# Altium schematic sheet Hardware.SchDoc(Hardware)
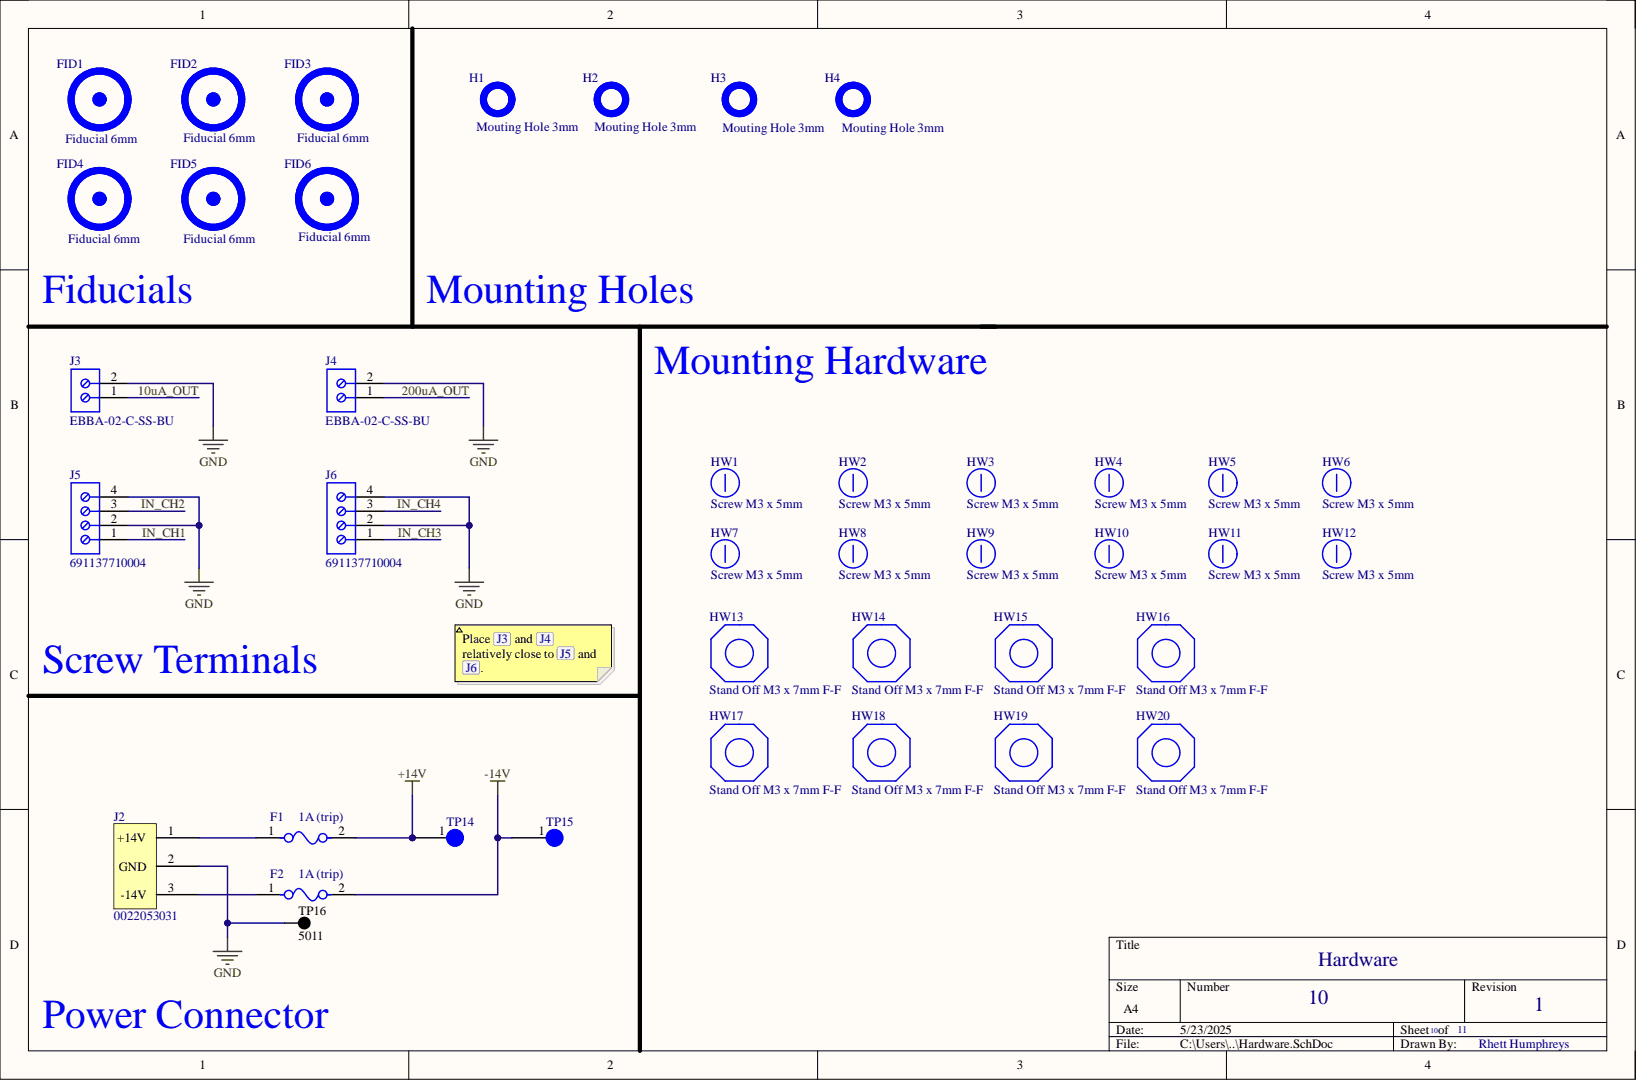
[diagram p0: Hardware.SchDoc]

## Parts
F1  1A (trip)  Fuse Current: 1A  [FP-0466-MFG]
F2  1A (trip)  Fuse Current: 1A  [FP-0466-MFG]
FID1  Fiducial 6mm  [Fiducial 6mm]
FID2  Fiducial 6mm  [Fiducial 6mm]
FID3  Fiducial 6mm  [Fiducial 6mm]
FID4  Fiducial 6mm  [Fiducial 6mm]
FID5  Fiducial 6mm  [Fiducial 6mm]
FID6  Fiducial 6mm  [Fiducial 6mm]
H1  Mouting Hole 3mm  [MH3]
H2  Mouting Hole 3mm  [MH3]
H3  Mouting Hole 3mm  [MH3]
H4  Mouting Hole 3mm  [MH3]
HW1  Screw M3 x 5mm
HW2  Screw M3 x 5mm
HW3  Screw M3 x 5mm
HW4  Screw M3 x 5mm
HW5  Screw M3 x 5mm
HW6  Screw M3 x 5mm
HW7  Screw M3 x 5mm
HW8  Screw M3 x 5mm
HW9  Screw M3 x 5mm
HW10  Screw M3 x 5mm
HW11  Screw M3 x 5mm
HW12  Screw M3 x 5mm
HW13  Stand Off M3 x 7mm F-F
HW14  Stand Off M3 x 7mm F-F
HW15  Stand Off M3 x 7mm F-F
HW16  Stand Off M3 x 7mm F-F
HW17  Stand Off M3 x 7mm F-F
HW18  Stand Off M3 x 7mm F-F
HW19  Stand Off M3 x 7mm F-F
HW20  Stand Off M3 x 7mm F-F
J2  0022053031  [0022053031]
J3  EBBA-02-C-SS-BU  [TECO-282836-2_V]
J4  EBBA-02-C-SS-BU  [TECO-282836-2_V]
J5  691137710004  [691137710004]
J6  691137710004  [691137710004]
TP14  Test-Point 3mm  [TestPoint 3mm]  (value not drawn)
TP15  Test-Point 3mm  [TestPoint 3mm]  (value not drawn)
TP16  5011  [KSTN-5011_V]

## Nets
+14V: F1.2 TP14.1
-14V: F2.2 TP15.1
GND: J2.2 J3.2 J4.2 J5.2 J5.4 J6.2 J6.4 TP16.1
10uA_OUT: J3.1
200uA_OUT: J4.1
IN_CH1: J5.1
IN_CH2: J5.3
IN_CH3: J6.1
IN_CH4: J6.3
NetF1_1: F1.1 J2.1
NetF2_1: F2.1 J2.3

## Library data of the parts
F1 F2 = CMP-08605-000058-1: FUSE BOARD MNT 1A 63VAC/VDC 1206; Manufacturer: Littelfuse Inc; Manufacturer Part Number: 0466001.NR; Fuse Blow Speed: Fast; Fuse I2T: 0.0423A2Sec; Fuse Interrupt Ac Current: 50A; Fuse Voltage Drop: 79.97mV; Max Fuse Ac Voltage: 63V; Resistance: 0.075Ω; Value: 0.075Ω; Automotive: No; Category: Fuse; Component Kind: Standard; ComponentLink2Description: Datasheet; ComponentLink2URL: https://www.littelfuse.com/~/media/electronics/datasheets/fuses/littelfuse_fuse_466_datasheet.pdf.pdf; Device Class L1: Circuit Protection; Device Class L2: Fuses; Device Class L3: unset; Digikey Description: FUSE BOARD MNT 1A 63VAC/VDC 1206; Fuse Interrupt Dc Current: 50A; Height: 0.66mm; Lead Free: Yes; Library Name: Altium Content Vault; Max Fuse Dc Voltage: 63V; Mouser Description: Surface Mount Fuses 63V FA 1206 1A VFA Slimline; Octopart: https://octopart.com/0466001.nr-littelfuse-566005; Package: 0466; RoHS: TRUE; Temperature: -55°C to +90°C; Temperature Range High: +90°C; Temperature Range Low: -55°C; Voltage Rating: 63V
H1-H4 = MH3: Component Kind: Standard; Library Name: Master.SchLib
HW1-HW12 = Screw M3 x 5mm: Fastener flathead M3 x 5mm; Component Kind: Standard; Library Name: Master.SchLib
HW13-HW20 = Stand Off M3 x 7mm F-F: Stand off hex M3 x 7mm; Component Kind: Standard; Library Name: Master.SchLib
J3 J4 = CMP-1684-00017-1: Female Header, Pitch 5 mm, 1 x 2 Position, Height 10 mm, Tail Length 3.5 mm, Rohs, Bulk; Manufacturer: TE Connectivity; 3D Model: 282836-2.pdf; Clamp Material - Plating: Brass-NickelPlated; Current: 13.5A; Dynamic Catalog: BuchananSeries; Featured Product: EurostyleTerminalBlockConnectors; Mating Orientation: HorizontalwithBoard; Number of Levels: 1; Other Names: 5ULV-02B  A98076; Pitch: 0.197"(5.00mm); Positions Per Level: 2; Product Training Modules: Wire-to-BoardEurostyleTerminalBlocks; Screw Thread: M3; Torque - Screw: 0.68Nm(6Lb-In); Voltage: 300V; Wire Gauge: 16-30AWG; Wire Termination: Screw-RisingCageClamp; Category: Connectors,Interconnects; Color: Green; Component Kind: Standard; Contact Material - Plating: Brass-TinPlated; Datasheet URL: http://www.te.com.cn/commerce/DocumentDelivery/DDEController?Action=showdoc&DocId=Catalog+Section%7F1308389_EUROSTYLE_TERMINAL_BLOCKS%7F0607%7Fpdf%7FEnglish%7FENG_CS_1308389_EUROSTYLE_TERMINAL_BLOCKS_0607.pdf%7F282836-2; Family: TerminalBlocks-WiretoBoard; Features: Interlocking(Side); Housing Material: Polyamide(PA66),Nylon6/6; Library Name: Altium Content Vault; Manufacturer URL: http://www.te.com; Mounting Type: ThroughHole; Package Description: Female Header, Pitch 5 mm, 1 x 2 Position, Height 10 mm, Tail Length 3.5 mm; Package Reference: THD; Packaging: Bulk; RoHS Information: 282836-2StatementofCompliance; Screw Material - Plating: Brass-NickelPlated; Series: Buchanan; Standard Package: 300
J5 J6 = CMP-1502-03326-2: NexarPartId: 78871140; Component Kind: Standard; Lead Pitch: 5mm; Library Name: Altium Content Vault; Number of Pins: 4; Packaging: Box; RoHS: Non-Compliant
TP16 = CMP-1672-00003-4: Test Point, Black, Through Hole, RoHS, Bulk; Manufacturer: Keystone; Colour: Black; Component Kind: Standard; ComponentLink1Description: Manufacturer URL; ComponentLink1URL: http://www.keyelco.com/; ComponentLink2Description: Datasheet; ComponentLink2URL: http://www.keyelco.com/product-pdf.cfm?p=1320; Contact Material: Bronze; Contact Plating: Silver; DatasheetVersion: Rev. F, 12/2013; Height: 8.12 mm; Library Name: Altium Content Vault; Mount: Through Hole; Mounting Technology: Through Hole; Package Quantity: 100; PackageDescription: 1-Pin Through Hole Device, Dia 3.17 mm; PackageReference: 5011; Packaging: Bulk; Pins: 1; REACH SVHC: No SVHC; RoHSCompliant: true
FID1-FID6 = Fiducial 6mm: Component Kind: Standard; Library Name: Master.SchLib
J2 = 0022053031: Component Kind: Standard; Library Name: Master.SchLib
TP14 TP15 = Test-Point 3mm: Component Kind: Standard; Library Name: Master.SchLib
